# Altium schematic sheet Atmega328.SchDoc
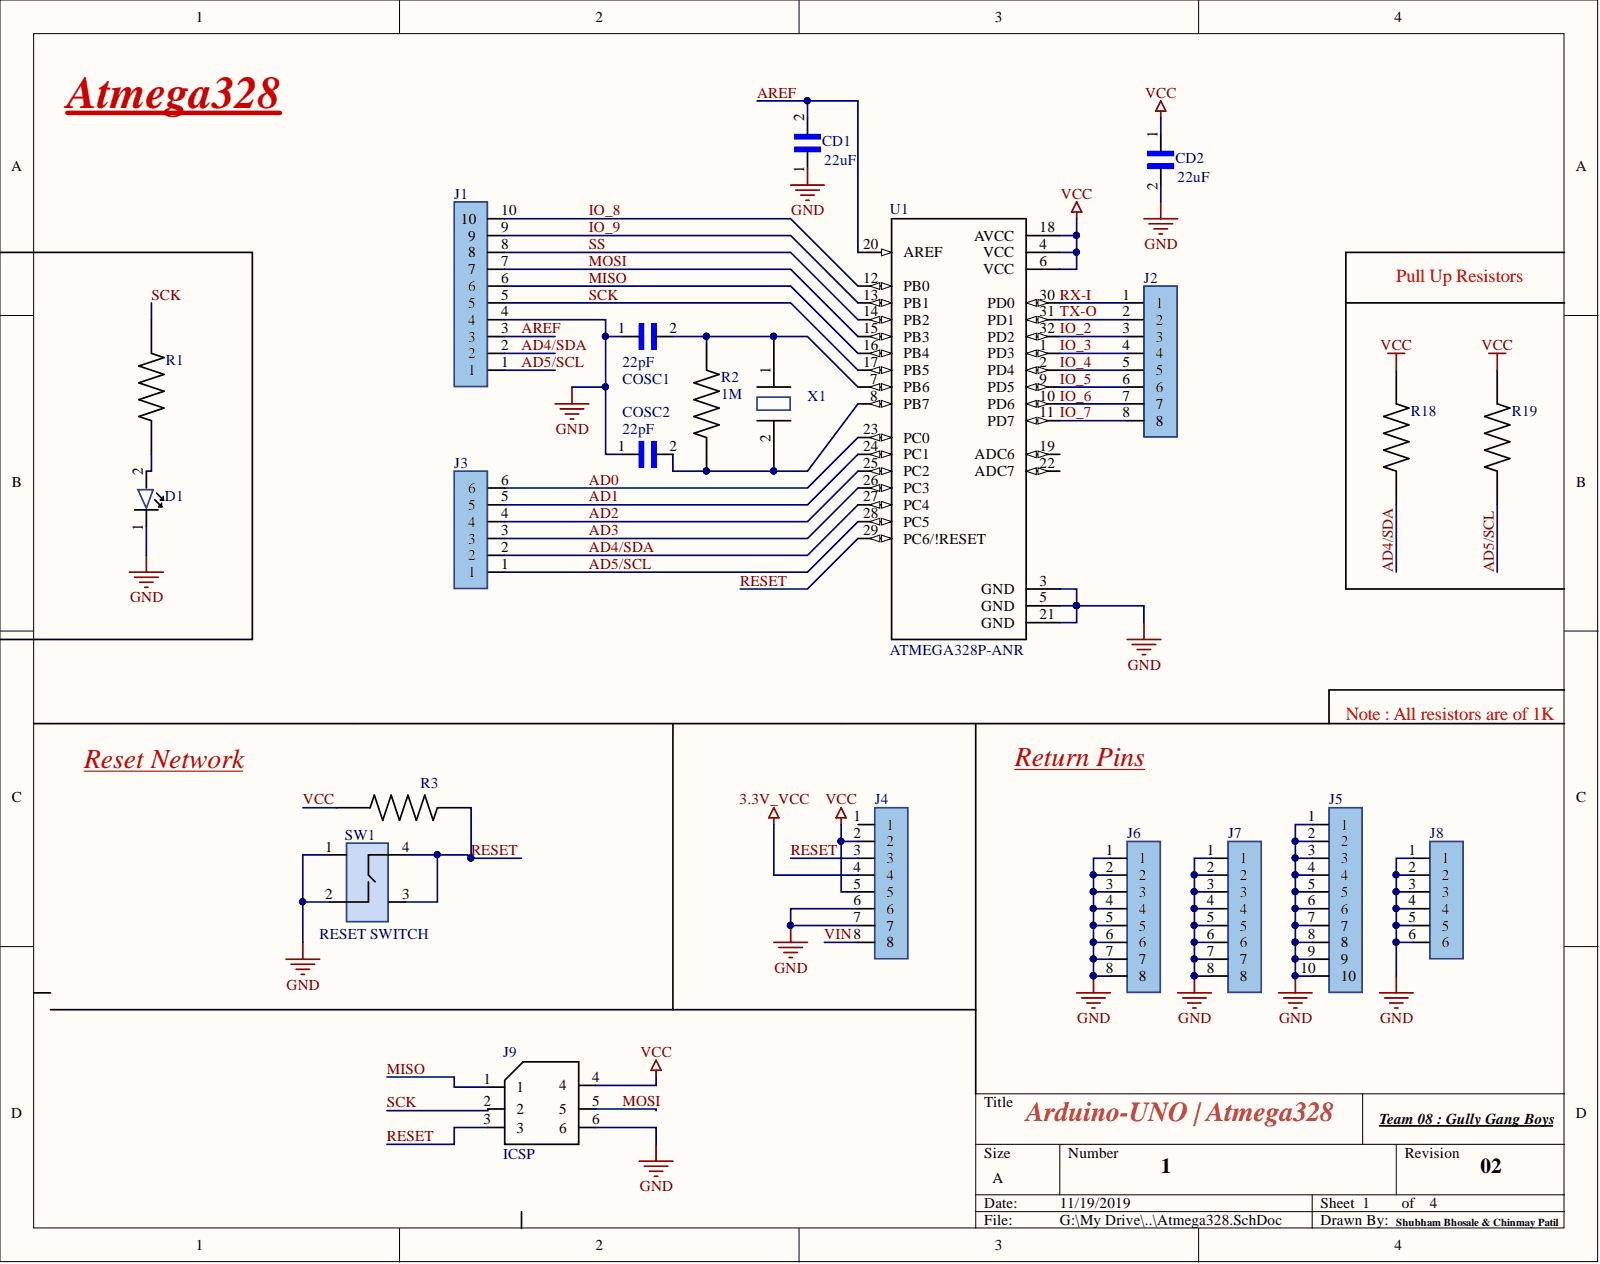
[diagram p0: Atmega328.SchDoc]

## Parts
CD1  22uF  [1206_Passive]
CD2  22uF  [1206_Passive]
COSC1  22pF  [1206_Passive]
COSC2  22pF  [1206_Passive]
D1  LTST-C150CKT  [LED]  (value not drawn)
J1  PPTC101LFBN-RC  [10_PIN]  (value not drawn)
J2  PPTC081LFBN-RC  [8_PIN]  (value not drawn)
J3  PPTC061LFBN-RC  [6_PIN]  (value not drawn)
J4  PPTC081LFBN-RC  [8_PIN]  (value not drawn)
J5  PPTC101LFBN-RC  [10_PIN]  (value not drawn)
J6  PPTC081LFBN-RC  [8_PIN]  (value not drawn)
J7  PPTC081LFBN-RC  [8_PIN]  (value not drawn)
J8  PPTC061LFBN-RC  [6_PIN]  (value not drawn)
J9  ICSP  [ICSP]
R1  RNCP1206FTD1K00  [1206_Passive]  (value not drawn)
R2  1M  [1206_Passive]
R3  RNCP1206FTD1K00  [1206_Passive]  (value not drawn)
R18  RNCP1206FTD1K00  [1206_Passive]  (value not drawn)
R19  RNCP1206FTD1K00  [1206_Passive]  (value not drawn)
SW1  RESET SWITCH  [Switch]
U1  ATMEGA328P-ANR  [ATMega328P]
X1  ABLS-16.000MHZ-B4-T  [Crystal]  (value not drawn)

## Nets
3.3V_VCC: J4.4
GND: CD1.1 CD2.2 COSC1.1 COSC2.1 D1.1 J1.4 J4.6 J4.7 J5.1 J5.2 J5.3 J5.4 J5.5 J5.6 J5.7 J5.8 J5.9 J5.10 J6.1 J6.2 J6.3 J6.4 J6.5 J6.6 J6.7 J6.8 J7.1 J7.2 J7.3 J7.4 J7.5 J7.6 J7.7 J7.8 J8.1 J8.2 J8.3 J8.4 J8.5 J8.6 J9.6 SW1.1 SW1.2 U1.3(GND) U1.5(GND) U1.21(GND)
AD0: J3.6 U1.23(PC0)
AD1: J3.5 U1.24(PC1)
AD2: J3.4 U1.25(PC2)
AD3: J3.3 U1.26(PC3)
AD4/SDA: J1.2 J3.2 R18.1 U1.27(PC4)
AD5/SCL: J1.1 J3.1 R19.1 U1.28(PC5)
AREF: CD1.2 J1.3 U1.20(AREF)
IO_2: J2.3 U1.32(PD2)
IO_3: J2.4 U1.1(PD3)
IO_4: J2.5 U1.2(PD4)
IO_5: J2.6 U1.9(PD5)
IO_6: J2.7 U1.10(PD6)
IO_7: J2.8 U1.11(PD7)
IO_8: J1.10 U1.12(PB0)
IO_9: J1.9 U1.13(PB1)
MISO: J1.6 J9.1 U1.16(PB4)
MOSI: J1.7 J9.5 U1.15(PB3)
RESET: J4.3 J9.3 R3.2 SW1.3 SW1.4 U1.29(PC6/!RESET)
RX-I: J2.1 U1.30(PD0)
SCK: J1.5 J9.2 R1.2 U1.17(PB5)
SS: J1.8 U1.14(PB2)
TX-O: J2.2 U1.31(PD1)
VCC: CD2.1 J4.2 J4.5 J9.4 R3.1 R18.2 R19.2 U1.4(VCC) U1.6(VCC) U1.18(AVCC)
VIN: J4.8
NetCOSC1_2: COSC1.2 R2.2 U1.7(PB6) X1.1
NetCOSC2_2: COSC2.2 R2.1 U1.8(PB7) X1.2
NetD1_2: D1.2 R1.1

Not connected: J4 1; U1 19(ADC6) 22(ADC7)

## Library data of the parts
CD1 CD2 = 1206_22uF: 22µF ±20% 16V Ceramic Capacitor X5R 1206 (3216 Metric); Value: CAP CER 22UF 16V X5R 1206; Component Kind: Standard; Digikey Link: https://www.digikey.com/product-detail/en/CL31A226MOCLNNC/1276-2728-1-ND/3890814/?itemSeq=304982363; Digikey Part: 1276-2728-1-ND; Library Name: brd2_rev2.IntLib
COSC1 COSC2 = 1206_22pF: 22pF ±5% 16V Ceramic Capacitor C0G, NP0 1206 (3216 Metric); Value: CAP CER 22PF 16V C0G/NP0 1206; Component Kind: Standard; Digikey Link: https://www.digikey.com/product-detail/en/885012008011/732-7867-1-ND/5454494/?itemSeq=304982362; Digikey Part: 732-7867-1-ND; Library Name: brd2_rev2.IntLib
D1 = 1206_RED_LED: Red 638nm LED Indication - Discrete 1.8V 1206 (3216 Metric); Value: LED RED CLEAR 1206 SMD; Component Kind: Standard; Digikey Link: https://www.digikey.com/product-detail/en/LTST-C150CKT/160-1167-1-ND/269239/?itemSeq=304982368; Digikey Part: 160-1167-1-ND; Library Name: brd2_rev2.IntLib
J1 J5 = 10_PIN_FEMALE: 10 Position Header Connector 0.100" (2.54mm) Through Hole Tin; Value: CONN HDR 10POS 0.1 TIN PCB; Component Kind: Standard; Digikey Link: https://www.digikey.com/product-detail/en/PPTC101LFBN-RC/S7008-ND/810149/?itemSeq=304982352; Digikey Part: S7008-ND; Library Name: brd2_rev2.IntLib
J2 J4 J6 J7 = 8_PIN_FEMALE: 8 Position Header Connector 0.100" (2.54mm) Through Hole Tin; Value: CONN HDR 8POS 0.1 TIN PCB; Component Kind: Standard; Digikey Link: https://www.digikey.com/product-detail/en/PPTC081LFBN-RC/S7006-ND/810147/?itemSeq=304982354; Digikey Part: S7006-ND; Library Name: brd2_rev2.IntLib
J3 J8 = 6_PIN_FEMALE: 6 Position Header Connector 0.100" (2.54mm) Through Hole Tin; Value: CONN HDR 6POS 0.1 TIN PCB; Component Kind: Standard; Digikey Link: https://www.digikey.com/product-detail/en/PPTC061LFBN-RC/S7004-ND/810145/?itemSeq=304982353; Digikey Part: S7004-ND; Library Name: brd2_rev2.IntLib
J9 = ICSP: Connector Header Through Hole 6 position 0.079" (2.00mm); Value: NRPN032PAEN-RC; Component Kind: Standard; Digikey Link: https://www.digikey.com/product-detail/en/NRPN032PAEN-RC/S5801-03-ND/804955/?itemSeq=304982355; Digikey Part: S5801-03-ND; Library Name: brd2_rev2.IntLib
R1 R3 R18 R19 = 1206_1K: 1 kOhms ±1% 0.5W, 1/2W Chip Resistor 1206 (3216 Metric) Anti-Sulfur, Moisture Resistant Thin Film; Value: RES 1K OHM 1% 1/2W 1206; Component Kind: Standard; Digikey Link: https://www.digikey.com/product-detail/en/RNCP1206FTD1K00/RNCP1206FTD1K00CT-ND/2240675/?itemSeq=304982373; Digikey Part: RNCP1206FTD1K00CT-ND; Library Name: brd2_rev2.IntLib
R2 = 1206_1M: 1 MOhms ±5% 0.25W, 1/4W Chip Resistor 1206 (3216 Metric) Automotive AEC-Q200 Thick Film; Value: RES 1M OHM 5% 1/4W 1206; Component Kind: Standard; Digikey Link: https://www.digikey.com/product-detail/en/RMCF1206JT1M00/RMCF1206JT1M00CT-ND/1942830/?itemSeq=304982358; Digikey Part: Number RMCF1206JT1M00CT-ND; Library Name: brd2_rev2.IntLib
SW1 = Push_Button: Tactile Switch SPST-NO Top Actuated Surface Mount; Value: SWITCH TACTILE SPST-NO 50MA 12V; Component Kind: Standard; Digikey Link: https://www.digikey.com/product-detail/en/TL3305AF160QG/EG5350CT-ND/5816195/?itemSeq=304982361; Digikey Part: EG5350CT-ND; Library Name: brd2_rev2.IntLib
U1 = ATMega328P: AVR AVR® ATmega Microcontroller IC 8-Bit 20MHz 32KB (16K x 16) FLASH 32-TQFP (7x7); Value: IC MCU 8BIT 32KB FLASH 32TQFP; Component Kind: Standard; Digikey Link: https://www.digikey.com/product-detail/en/ATMEGA328P-ANR/ATMEGA328P-ANRCT-ND/2774230/?itemSeq=304982365; Digikey Part: ATMEGA328P-ANRCT-ND; Library Name: brd2_rev2.IntLib
X1 = 16MHz_Crystal: 16MHz ±30ppm Crystal 18pF 40 Ohms HC-49/US; Value: CRYSTAL 16.0000MHZ 18PF SMD; Component Kind: Standard; Digikey Link: https://www.digikey.com/product-detail/en/ABLS-16.000MHZ-B4-T/535-10226-1-ND/2184261/?itemSeq=304982364; Digikey Part: 535-10226-1-ND; Library Name: brd2_rev2.IntLib
